# Altium schematic sheet Sheet2.SchDoc(Sheet2)
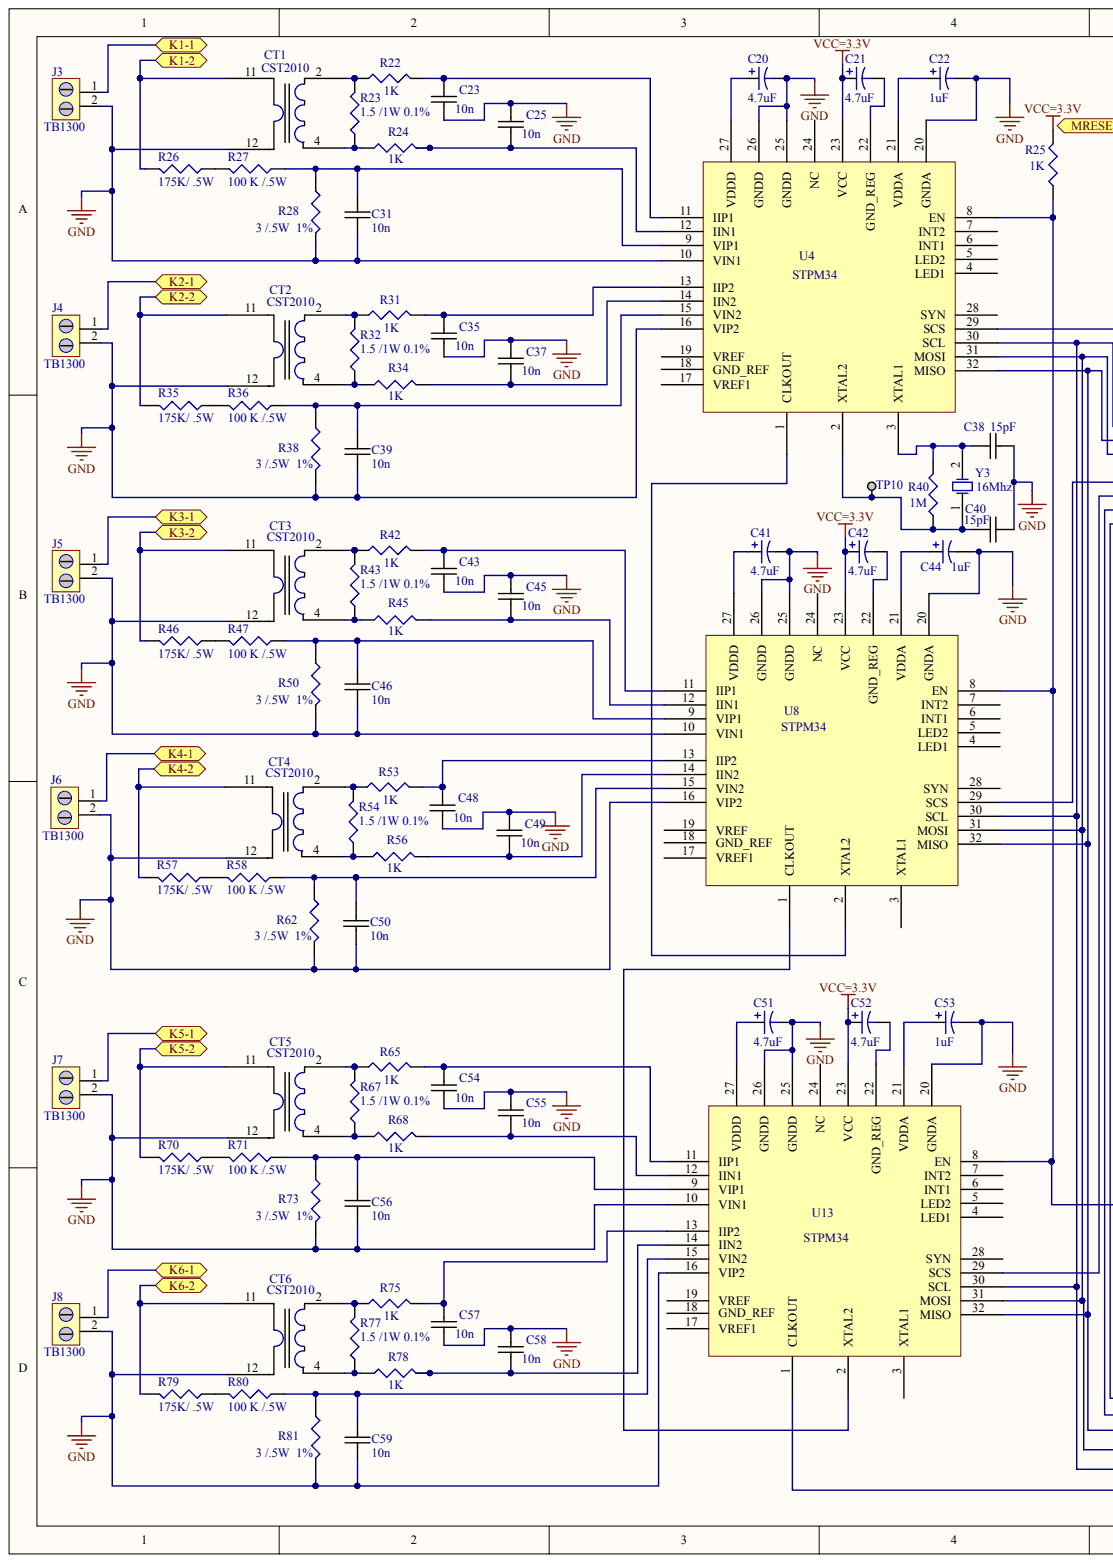
[diagram p0: Sheet2.SchDoc, left]
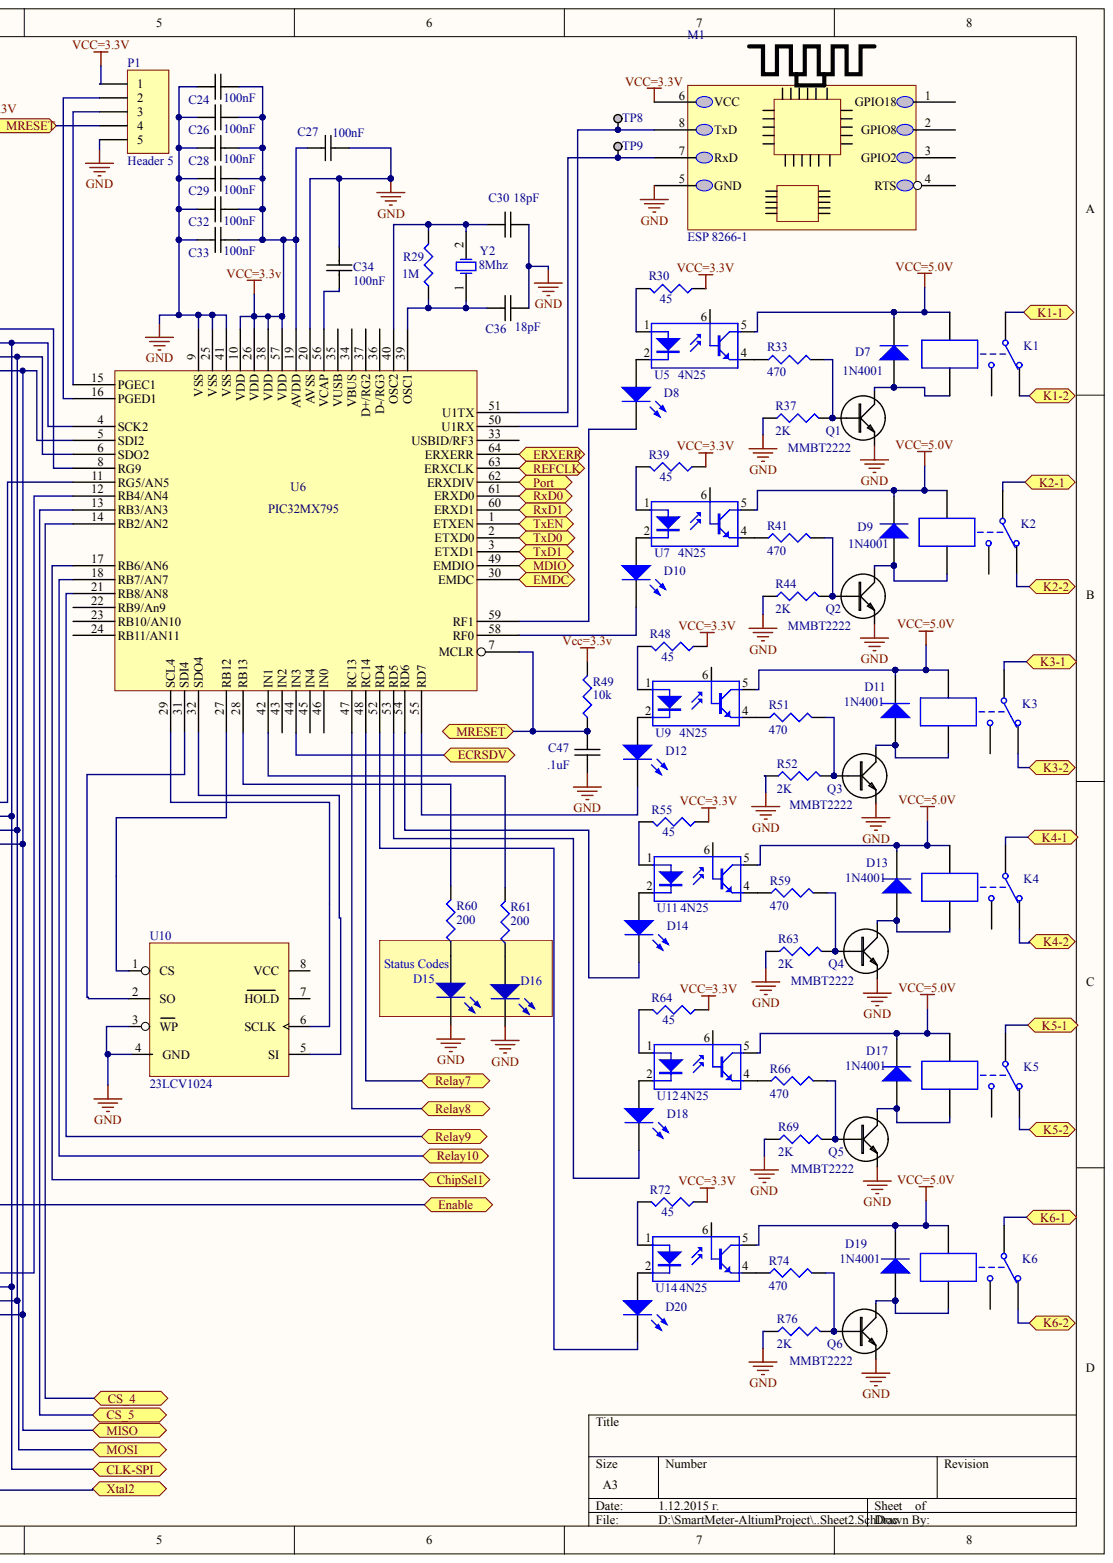
[diagram p1: Sheet2.SchDoc, right]

## Parts
C20  4.7uF
C21  4.7uF
C22  1uF
C23  10n
C24  100nF
C25  10n
C26  100nF
C27  100nF
C28  100nF
C29  100nF
C30  18pF
C31  10n
C32  100nF
C33  100nF
C34  100nF
C35  10n
C36  18pF
C37  10n
C38  15pF
C39  10n
C40  15pF
C41  4.7uF
C42  4.7uF
C43  10n
C44  1uF
C45  10n
C46  10n
C47  .1uF
C48  10n
C49  10n
C50  10n
C51  4.7uF
C52  4.7uF
C53  1uF
C54  10n
C55  10n
C56  10n
C57  10n
C58  10n
C59  10n
CT1  CST2010
CT2  CST2010
CT3  CST2010
CT4  CST2010
CT5  CST2010
CT6  CST2010
D7  1N4001
D8  LED2  (value not drawn)
D9  1N4001
D10  LED2  (value not drawn)
D11  1N4001
D12  LED2  (value not drawn)
D13  1N4001
D14  LED2  (value not drawn)
D15  LED2  (value not drawn)
D16  LED2  (value not drawn)
D17  1N4001
D18  LED2  (value not drawn)
D19  1N4001
D20  LED2  (value not drawn)
J3  TB1300
J4  TB1300
J5  TB1300
J6  TB1300
J7  TB1300
J8  TB1300
K1  Relay-SPDT  (value not drawn)
K2  Relay-SPDT  (value not drawn)
K3  Relay-SPDT  (value not drawn)
K4  Relay-SPDT  (value not drawn)
K5  Relay-SPDT  (value not drawn)
K6  Relay-SPDT  (value not drawn)
M1  ESP 8266-1
P1  Header 5
Q1  MMBT2222
Q2  MMBT2222
Q3  MMBT2222
Q4  MMBT2222
Q5  MMBT2222
Q6  MMBT2222
R22  1K
R23  1.5 /1W 0.1%
R24  1K
R25  1K
R26  175K/ .5W
R27  100 K /.5W
R28  3 /.5W  1%
R29  1M
R30  45
R31  1K
R32  1.5 /1W 0.1%
R33  470
R34  1K
R35  175K/ .5W
R36  100 K /.5W
R37  2K
R38  3 /.5W  1%
R39  45
R40  1M
R41  470
R42  1K
R43  1.5 /1W 0.1%
R44  2K
R45  1K
R46  175K/ .5W
R47  100 K /.5W
R48  45
R49  10k
R50  3 /.5W  1%
R51  470
R52  2K
R53  1K
R54  1.5 /1W 0.1%
R55  45
R56  1K
R57  175K/ .5W
R58  100 K /.5W
R59  470
R60  200
R61  200
R62  3 /.5W  1%
R63  2K
R64  45
R65  1K
R66  470
R67  1.5 /1W 0.1%
R68  1K
R69  2K
R70  175K/ .5W
R71  100 K /.5W
R72  45
R73  3 /.5W  1%
R74  470
R75  1K
R76  2K
R77  1.5 /1W 0.1%
R78  1K
R79  175K/ .5W
R80  100 K /.5W
R81  3 /.5W  1%
TP8  TESTPOINT  (value not drawn)
TP9  TESTPOINT  (value not drawn)
TP10  TESTPOINT  (value not drawn)
U4  STPM34
U5  4N25
U6  PIC32MX795
U7  4N25
U8  STPM34
U9  4N25
U10  23LCV1024
U11  4N25
U12  4N25
U13  STPM34
U14  4N25
Y2  8Mhz
Y3  16Mhz

## Ports
CHIPSEL1, CLK-SPI, CS_4, CS_5, ECRSDV, EMDC, ENABLE, ERXERR, K1-1, K1-2, K2-1, K2-2, K3-1, K3-2, K4-1, K4-2, K5-1, K5-2, K6-1, K6-2, MDIO, MISO, MOSI, MRESET, PORT, REFCLK, RELAY7, RELAY8, RELAY9, RELAY10, RXD0, RXD1, TXD0, TXD1, TXEN, XTAL2

## Nets
GND: C20.2 C22.2 C23.1 C24.1 C25.2 C26.1 C27.2 C28.1 C29.1 C30.2 C31.1 C32.1 C33.1 C34.2 C35.1 C36.2 C37.2 C38.2 C39.1 C40.2 C41.2 C43.1 C44.2 C45.2 C46.1 C47.1 C48.1 C49.2 C50.1 C51.2 C53.2 C54.1 C55.2 C56.1 C57.1 C58.2 C59.1 CT1.12 CT2.12 CT3.12 CT4.12 CT5.12 CT6.12 D15.2 D16.2 J3.2 J4.2 J5.2 J6.2 J7.2 J8.2 M1.5(GND) P1.5 Q1.E Q2.E Q3.E Q4.E Q5.E Q6.E R28.2 R37.1 R38.2 R44.1 R50.2 R52.1 R62.2 R63.1 R69.1 R73.2 R76.1 R81.2 U4.10(VIN1) U4.16(VIP2) U4.20(GNDA) U4.25(GNDD) U4.26(GNDD) U6.9(VSS) U6.20(AVSS) U6.25(VSS) U6.41(VSS) U8.10(VIN1) U8.16(VIP2) U8.20(GNDA) U8.25(GNDD) U8.26(GNDD) U10.3(WP) U10.4(GND) U13.10(VIN1) U13.16(VIP2) U13.20(GNDA) U13.25(GNDD) U13.26(GNDD)
VCC=3.3V: C21.1 C24.2 C26.2 C27.1 C28.2 C29.2 C32.2 C33.2 C42.1 C52.1 M1.6(VCC) P1.1 R25.2 R30.1 R39.1 R48.1 R49.2 R55.1 R64.1 R72.1 U4.23(VCC) U6.10(VDD) U6.19(AVDD) U6.26(VDD) U6.38(VDD) U6.57(VDD) U8.23(VCC) U13.23(VCC)
VCC=5.0V: D7.2 D9.2 D11.2 D13.2 D17.2 D19.2 K1.4 K2.4 K3.4 K4.4 K5.4 K6.4 U5.5 U7.5 U9.5 U11.5 U12.5 U14.5
CLK-SPI: U4.30(SCL) U6.4(SCK2) U8.30(SCL) U13.30(SCL) ; port CLK-SPI
CS_4: U6.14(RB2/AN2) ; port CS_4
CS_5: U6.13(RB3/AN3) ; port CS_5
ECRSDV: U6.44(IN3) ; port ECRSDV
EMDC: U6.30(EMDC) ; port EMDC
ERXERR: U6.64(ERXERR) ; port ERXERR
K1-1: J3.1 K1.1 ; port K1-1
K1-2: CT1.11 K1.2 R26.2 ; port K1-2
K2-1: J4.1 K2.1 ; port K2-1
K2-2: CT2.11 K2.2 R35.2 ; port K2-2
K3-1: J5.1 K3.1 ; port K3-1
K3-2: CT3.11 K3.2 R46.2 ; port K3-2
K4-1: J6.1 K4.1 ; port K4-1
K4-2: CT4.11 K4.2 R57.2 ; port K4-2
K5-1: J7.1 K5.1 ; port K5-1
K5-2: CT5.11 K5.2 R70.2 ; port K5-2
K6-1: J8.1 K6.1 ; port K6-1
K6-2: CT6.11 K6.2 R79.2 ; port K6-2
MDIO: U6.49(EMDIO) ; port MDIO
MISO: U4.32(MISO) U6.5(SDI2) U8.32(MISO) U13.32(MISO) ; port MISO
MOSI: U4.31(MOSI) U6.6(SDO2) U8.31(MOSI) U13.31(MOSI) ; port MOSI
MRESET: C47.2 P1.4 R49.1 U6.7(MCLR) ; port MRESET
REFCLK: U6.63(ERXCLK) ; port REFCLK
NetC20_1: C20.1 U4.27(VDDD)
NetC21_2: C21.2 U4.22(GND_REG)
NetC22_1: C22.1 U4.21(VDDA)
NetC23_2: C23.2 R22.2 U4.11(IIP1)
NetC25_1: C25.1 R24.2 U4.12(IIN1)
NetC30_1: C30.1 R29.2 U6.40(OSC2) Y2.2
NetC31_2: C31.2 R27.1 R28.1 U4.9(VIP1)
NetC34_1: C34.1 U6.56(VCAP)
NetC35_2: C35.2 R31.2 U4.13(IIP2)
NetC36_1: C36.1 R29.1 U6.39(OSC1) Y2.1
NetC37_1: C37.1 R34.2 U4.14(IIN2)
NetC38_1: C38.1 R40.2 U4.3(XTAL1) Y3.2
NetC39_2: C39.2 R36.1 R38.1 U4.15(VIN2)
NetC40_1: C40.1 R40.1 TP10.1 U4.2(XTAL2) Y3.1
NetC41_1: C41.1 U8.27(VDDD)
NetC42_2: C42.2 U8.22(GND_REG)
NetC43_2: C43.2 R42.2 U8.11(IIP1)
NetC44_1: C44.1 U8.21(VDDA)
NetC45_1: C45.1 R45.2 U8.12(IIN1)
NetC46_2: C46.2 R47.1 R50.1 U8.9(VIP1)
NetC48_2: C48.2 R53.2 U8.13(IIP2)
NetC49_1: C49.1 R56.2 U8.14(IIN2)
NetC50_2: C50.2 R58.1 R62.1 U8.15(VIN2)
NetC51_1: C51.1 U13.27(VDDD)
NetC52_2: C52.2 U13.22(GND_REG)
NetC53_1: C53.1 U13.21(VDDA)
NetC54_2: C54.2 R65.2 U13.11(IIP1)
NetC55_1: C55.1 R68.2 U13.12(IIN1)
NetC56_2: C56.2 R71.1 R73.1 U13.9(VIP1)
NetC57_2: C57.2 R75.2 U13.13(IIP2)
NetC58_1: C58.1 R78.2 U13.14(IIN2)
NetC59_2: C59.2 R80.1 R81.1 U13.15(VIN2)
NetCT1_2: CT1.2 R22.1 R23.2
NetCT1_4: CT1.4 R23.1 R24.1
NetCT2_2: CT2.2 R31.1 R32.2
NetCT2_4: CT2.4 R32.1 R34.1
NetCT3_2: CT3.2 R42.1 R43.2
NetCT3_4: CT3.4 R43.1 R45.1
NetCT4_2: CT4.2 R53.1 R54.2
NetCT4_4: CT4.4 R54.1 R56.1
NetCT5_2: CT5.2 R65.1 R67.2
NetCT5_4: CT5.4 R67.1 R68.1
NetCT6_2: CT6.2 R75.1 R77.2
NetCT6_4: CT6.4 R77.1 R78.1
NetD7_1: D7.1 K1.5 Q1.C
NetD8_1: D8.1 U5.2
NetD8_2: D8.2 U6.59(RF1)
NetD9_1: D9.1 K2.5 Q2.C
NetD10_1: D10.1 U7.2
NetD10_2: D10.2 U6.58(RF0)
NetD11_1: D11.1 K3.5 Q3.C
NetD12_1: D12.1 U9.2
NetD12_2: D12.2 U6.55(RD7)
NetD13_1: D13.1 K4.5 Q4.C
NetD14_1: D14.1 U11.2
NetD14_2: D14.2 U6.54(RD6)
NetD15_1: D15.1 R60.1
NetD16_1: D16.1 R61.1
NetD17_1: D17.1 K5.5 Q5.C
NetD18_1: D18.1 U12.2
NetD18_2: D18.2 U6.53(RD5)
NetD19_1: D19.1 K6.5 Q6.C
NetD20_1: D20.1 U14.2
NetD20_2: D20.2 U6.52(RD4)
NetM1_7: M1.7(RxD) TP9.1 U6.51(U1TX)
NetM1_8: M1.8(TxD) TP8.1 U6.50(U1RX)
NetP1_2: P1.2 U6.16(PGED1)
NetP1_3: P1.3 U6.15(PGEC1)
NetQ1_B: Q1.B R33.2 R37.2
NetQ2_B: Q2.B R41.2 R44.2
NetQ3_B: Q3.B R51.2 R52.2
NetQ4_B: Q4.B R59.2 R63.2
NetQ5_B: Q5.B R66.2 R69.2
NetQ6_B: Q6.B R74.2 R76.2
NetR25_1: R25.1 U4.8(EN) U8.8(EN) U13.8(EN) ; port ENABLE
NetR26_1: R26.1 R27.2
NetR30_2: R30.2 U5.1
NetR33_1: R33.1 U5.4
NetR35_1: R35.1 R36.2
NetR39_2: R39.2 U7.1
NetR41_1: R41.1 U7.4
NetR46_1: R46.1 R47.2
NetR48_2: R48.2 U9.1
NetR51_1: R51.1 U9.4
NetR55_2: R55.2 U11.1
NetR57_1: R57.1 R58.2
NetR59_1: R59.1 U11.4
NetR60_2: R60.2 U6.28(RB13)
NetR61_2: R61.2 U6.42(IN1)
NetR64_2: R64.2 U12.1
NetR66_1: R66.1 U12.4
NetR70_1: R70.1 R71.2
NetR72_2: R72.2 U14.1
NetR74_1: R74.1 U14.4
NetR79_1: R79.1 R80.2
NetU4_1: U4.1(CLKOUT) U8.2(XTAL2)
NetU4_29: U4.29(SCS) U6.8(RG9)
NetU6_1: U6.1(ETXEN) ; port TXEN
NetU6_2: U6.2(ETXD0) ; port TXD0
NetU6_3: U6.3(ETXD1) ; port TXD1
NetU6_11: U6.11(RG5/AN5) U8.29(SCS)
NetU6_12: U6.12(RB4/AN4) U13.29(SCS)
NetU6_17: U6.17(RB6/AN6) ; port CHIPSEL1
NetU6_18: U6.18(RB7/AN7) ; port RELAY10
NetU6_21: U6.21(RB8/AN8) ; port RELAY9
NetU6_27: U6.27(RB12) U10.1(CS)
NetU6_29: U6.29(SCL4) U10.6(SCLK)
NetU6_31: U6.31(SDI4) U10.2(SO)
NetU6_32: U6.32(SDO4) U10.5(SI)
NetU6_47: U6.47(RC13) ; port RELAY8
NetU6_48: U6.48(RC14) ; port RELAY7
NetU6_60: U6.60(ERXD1) ; port RXD1
NetU6_61: U6.61(ERXD0) ; port RXD0
NetU6_62: U6.62(ERXDIV) ; port PORT
NetU8_1: U8.1(CLKOUT) U13.2(XTAL2)
NetU13_1: U13.1(CLKOUT) ; port XTAL2

Not connected: K1 3; K2 3; K3 3; K4 3; K5 3; K6 3; M1 1(GPIO18) 2(GPIO8) 3(GPIO2) 4(RTS); U4 4(LED1) 5(LED2) 6(INT1) 7(INT2) 17(VREF1) 18(GND_REF) 19(VREF) 24(NC) 28(SYN); U5 6; U6 22(RB9/An9) 23(RB10/AN10) 24(RB11/AN11) 33(USBID/RF3) 34(VBUS) 35(VUSB) 36(D-/RG3) 37(D+/RG2) 43(IN2) 45(IN4) 46(IN0); U7 6; U8 3(XTAL1) 4(LED1) 5(LED2) 6(INT1) 7(INT2) 17(VREF1) 18(GND_REF) 19(VREF) 24(NC) 28(SYN); U9 6; U10 7(HOLD) 8(VCC); U11 6; U12 6; U13 3(XTAL1) 4(LED1) 5(LED2) 6(INT1) 7(INT2) 17(VREF1) 18(GND_REF) 19(VREF) 24(NC) 28(SYN); U14 6

## Library data of the parts
C20-C22 C41 C42 C44 C51-C53 = (no symbol name): Value: 100pF; Published: 8-Jun-2000; LatestRevisionDate: 29-May-2009; LatestRevisionNote: IPC-7351 Footprint Added.; PackageReference: C0805; PackageDescription: Chip Capacitor; Publisher: Altium Limited
R22-R25 R29 R31 R32 R34 R40 R42 R43 R45 R53 R54 R56 R65 R67 R68 R75 R77 R78 = (no symbol name): Value: 1K 1%; Published: 8-Jun-2000; LatestRevisionDate: 29-May-2009; LatestRevisionNote: IPC-7351 Footprint Added.; PackageReference: J1-0603; PackageDescription: Chip Resistor; Publisher: Altium Limited
  R24 R25 R29 R34 R40 R45 R56 R68 R78: manufacture P/N: *
R26 R35 R46 R57 R70 R79 = (no symbol name): Value: 175k/ .5 W 1%; Published: 8-Jun-2000; LatestRevisionDate: 29-May-2009; LatestRevisionNote: IPC-7351 Footprint Added.; PackageReference: J1-0603; PackageDescription: Chip Resistor; mfr PN: *; Package Reference: 1206; Publisher: Altium Limited
R27 R36 R47 R58 R71 R80 = (no symbol name): Value: 100k /.5 W 1%; Published: 8-Jun-2000; LatestRevisionDate: 29-May-2009; LatestRevisionNote: IPC-7351 Footprint Added.; PackageReference: J1-0603; PackageDescription: Chip Resistor; mfr PN: *; Package Reference: 1206; Publisher: Altium Limited
R28 R38 R50 R62 R73 R81 = (no symbol name): Value: 3 .125W 1%; Published: 8-Jun-2000; LatestRevisionDate: 29-May-2009; LatestRevisionNote: IPC-7351 Footprint Added.; PackageReference: J1-0603; PackageDescription: Chip Resistor; Publisher: Altium Limited
R30 R39 R48 R55 R64 R72 = (no symbol name): Value: 45/.125/1%; Published: 8-Jun-2000; LatestRevisionDate: 29-May-2009; LatestRevisionNote: IPC-7351 Footprint Added.; PackageReference: J1-0603; PackageDescription: Chip Resistor; mfr PN: *; Publisher: Altium Limited
R33 R41 R51 R59 R66 R74 = (no symbol name): Value: 470/.125W/1%; Published: 8-Jun-2000; LatestRevisionDate: 29-May-2009; LatestRevisionNote: IPC-7351 Footprint Added.; PackageReference: J1-0603; PackageDescription: Chip Resistor; Publisher: Altium Limited
R37 R44 R52 R63 R69 R76 = (no symbol name): Value: 2K/.125W/1%; Published: 8-Jun-2000; LatestRevisionDate: 29-May-2009; LatestRevisionNote: IPC-7351 Footprint Added.; PackageReference: J1-0603; PackageDescription: Chip Resistor; Publisher: Altium Limited
R49 = (no symbol name): Value: 10k/.125W/1%; Published: 8-Jun-2000; LatestRevisionDate: 29-May-2009; LatestRevisionNote: IPC-7351 Footprint Added.; PackageReference: J1-0603; PackageDescription: Chip Resistor; Publisher: Altium Limited
R60 R61 = (no symbol name): Value: 200/.125W/1%; Published: 8-Jun-2000; LatestRevisionDate: 29-May-2009; LatestRevisionNote: IPC-7351 Footprint Added.; PackageReference: J1-0603; PackageDescription: Chip Resistor; Publisher: Altium Limited
TP8-TP10 = (no symbol name): Manufacturer Part Number: 5010; Manufacturer: Keystone Electronics; Reel: TH; Supplier 1: Digi-Key; Supplier Part Number 1: 5010K-ND
C23-C40 C43 C45-C50 C54-C59 CT1-CT6 D7-D20 J3-J8 K1-K6 M1 P1 Q1-Q6 U4-U14 Y2 Y3 = (no symbol name)
  C23 C25 C35 C37 C43 C45 C48 C49 C54 C55 C57 C58: Supplier 1: Digi-Key; Supplier Part Number 1: 709-1171-2-ND; Value: 10n; mfr PN: *; Package reference: 0805-TBD
  C24 C26-C30 C32-C34 C36 C38 C40 C47: Supplier 1: Digi-Key; Supplier Part Number 1: 709-1171-2-ND
  C31 C39 C46 C50 C56 C59: Supplier 1: Digi-Key; Supplier Part Number 1: 709-1171-2-ND; Value: 10n; mfr PN: *; Package Reference: 0805-TBD
  D7 D9 D11 D13 D17 D19: Published: 8-Jun-2000; LatestRevisionDate: 17-Jul-2002; LatestRevisionNote: Re-released for DXP Platform.; DatasheetVersion: 1998; PackageVersion: Aug-1999; PackageReference: DO-41; PackageDescription: Diode, Axial; Body 5.2 x 2.7 mm (LxDia. max), Lead Dia. 0.86 mm (max); Code_JEDEC: DO-204-AL; ComponentLink1Description: Manufacturer Link; ComponentLink1URL: http://www.fairchildsemi.com/; ComponentLink2Description: Datasheet; ComponentLink2URL: http://www.fairchildsemi.com/ds/1N%2F1N4001.pdf
  D8 D10 D12 D14-D16 D18 D20: Published: 8-Jun-2000; LatestRevisionDate: 17-Jul-2002; LatestRevisionNote: Re-released for DXP Platform.; PackageVersion: Feb-2002; PackageReference: 3.2X1.6X1.1; PackageDescription: SM LED; 2 Flat Leads
  K1-K6: Published: 24-Mar-1999; LatestRevisionDate: 17-Jul-2002; LatestRevisionNote: Re-released for DXP Platform.; PackageReference: MODULE5B
  P1: LatestRevisionDate: 17-Jul-2002; LatestRevisionNote: Re-released for DXP Platform.
  Q1-Q6: Supplier 1: Digi-Key; Supplier Part Number 1: MMBT2222A-FDICT-ND
  U5 U7 U9 U11 U12 U14: Published: 24-Mar-1999; LatestRevisionDate: 04-Mar-2005; LatestRevisionNote: PCB Footprint replaced, Sch pins re-numbered.; DatasheetVersion: Apr-2004; PackageReference: SOP5(6); PackageDescription: Small Outline; 6 Leads (1 Absent); Body Width 4.4 mm; Pitch 1.27 mm
  Y2 Y3: Published: 8-Jun-2000; LatestRevisionDate: 17-Jul-2002; LatestRevisionNote: Re-released for DXP Platform.; PackageReference: R38; PackageDescription: Crystal, Thru-Hole; 2 Leads; Body 3.1 x 8.2 mm (Dia.xH)
  D7-D20 K1-K6 P1 U5 U7 U9 U11 U12 U14 Y2 Y3: Publisher: Altium Limited
